# Altium schematic sheet Sheet1.SchDoc
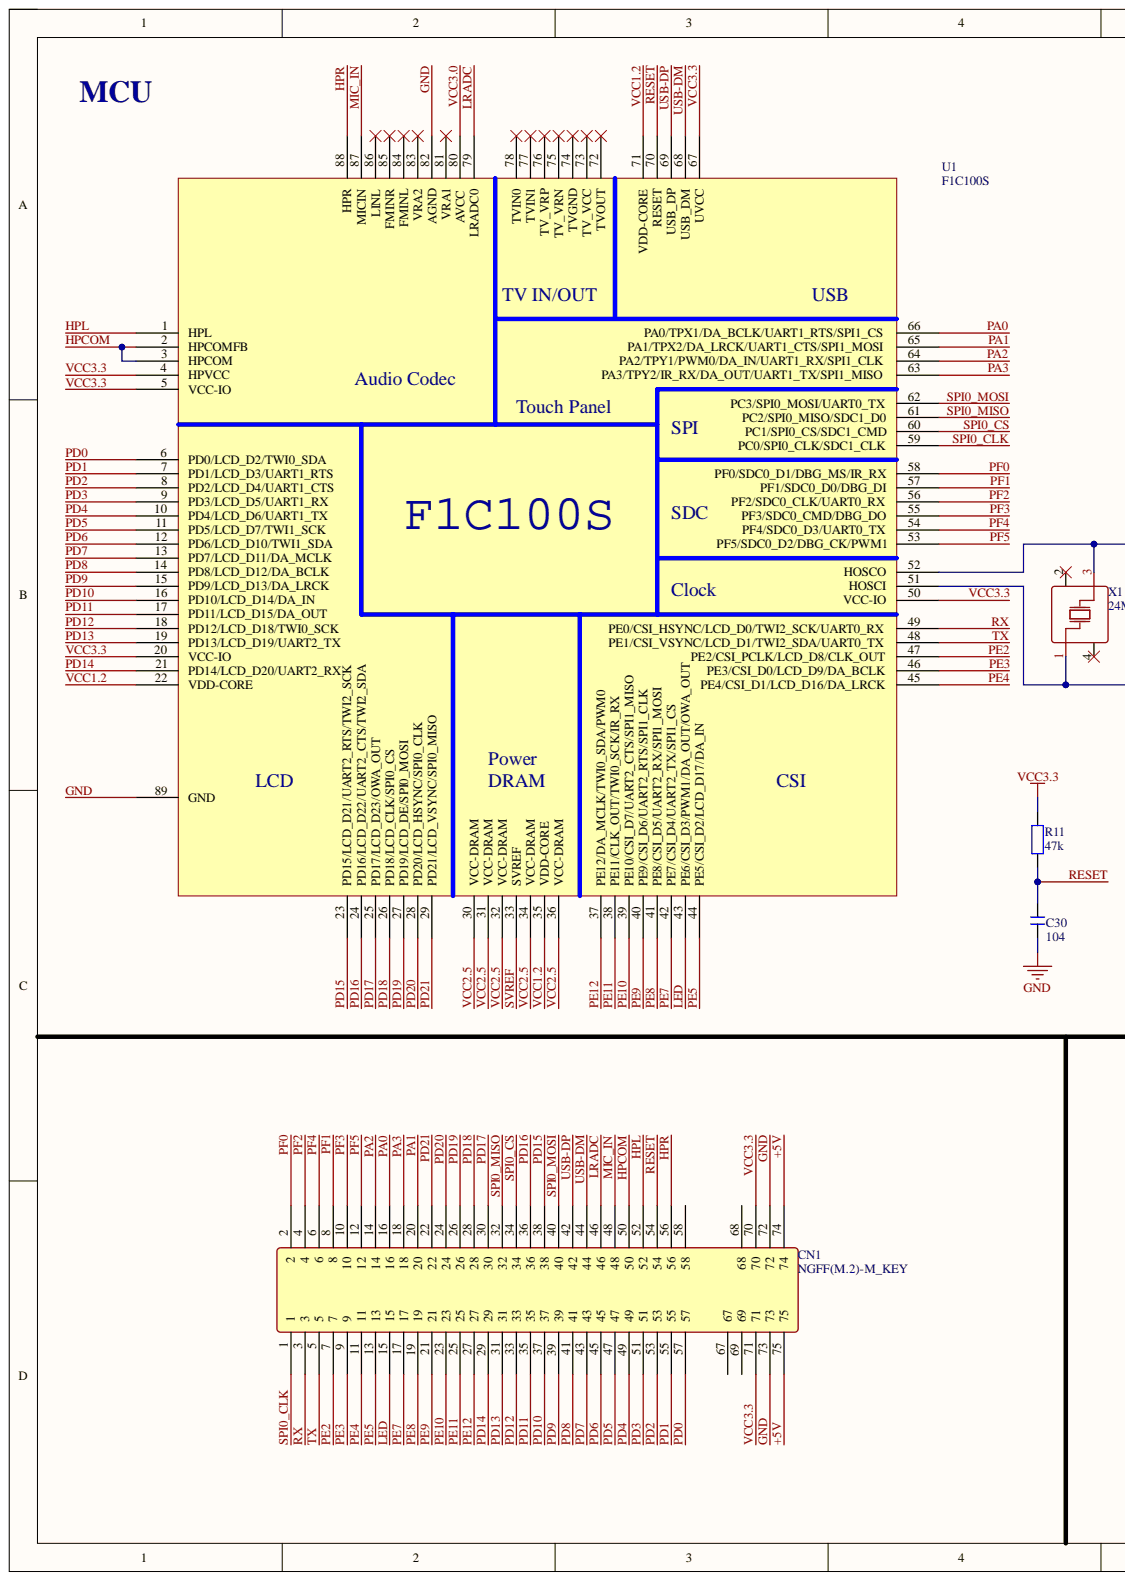
[diagram p0: Sheet1.SchDoc, left]
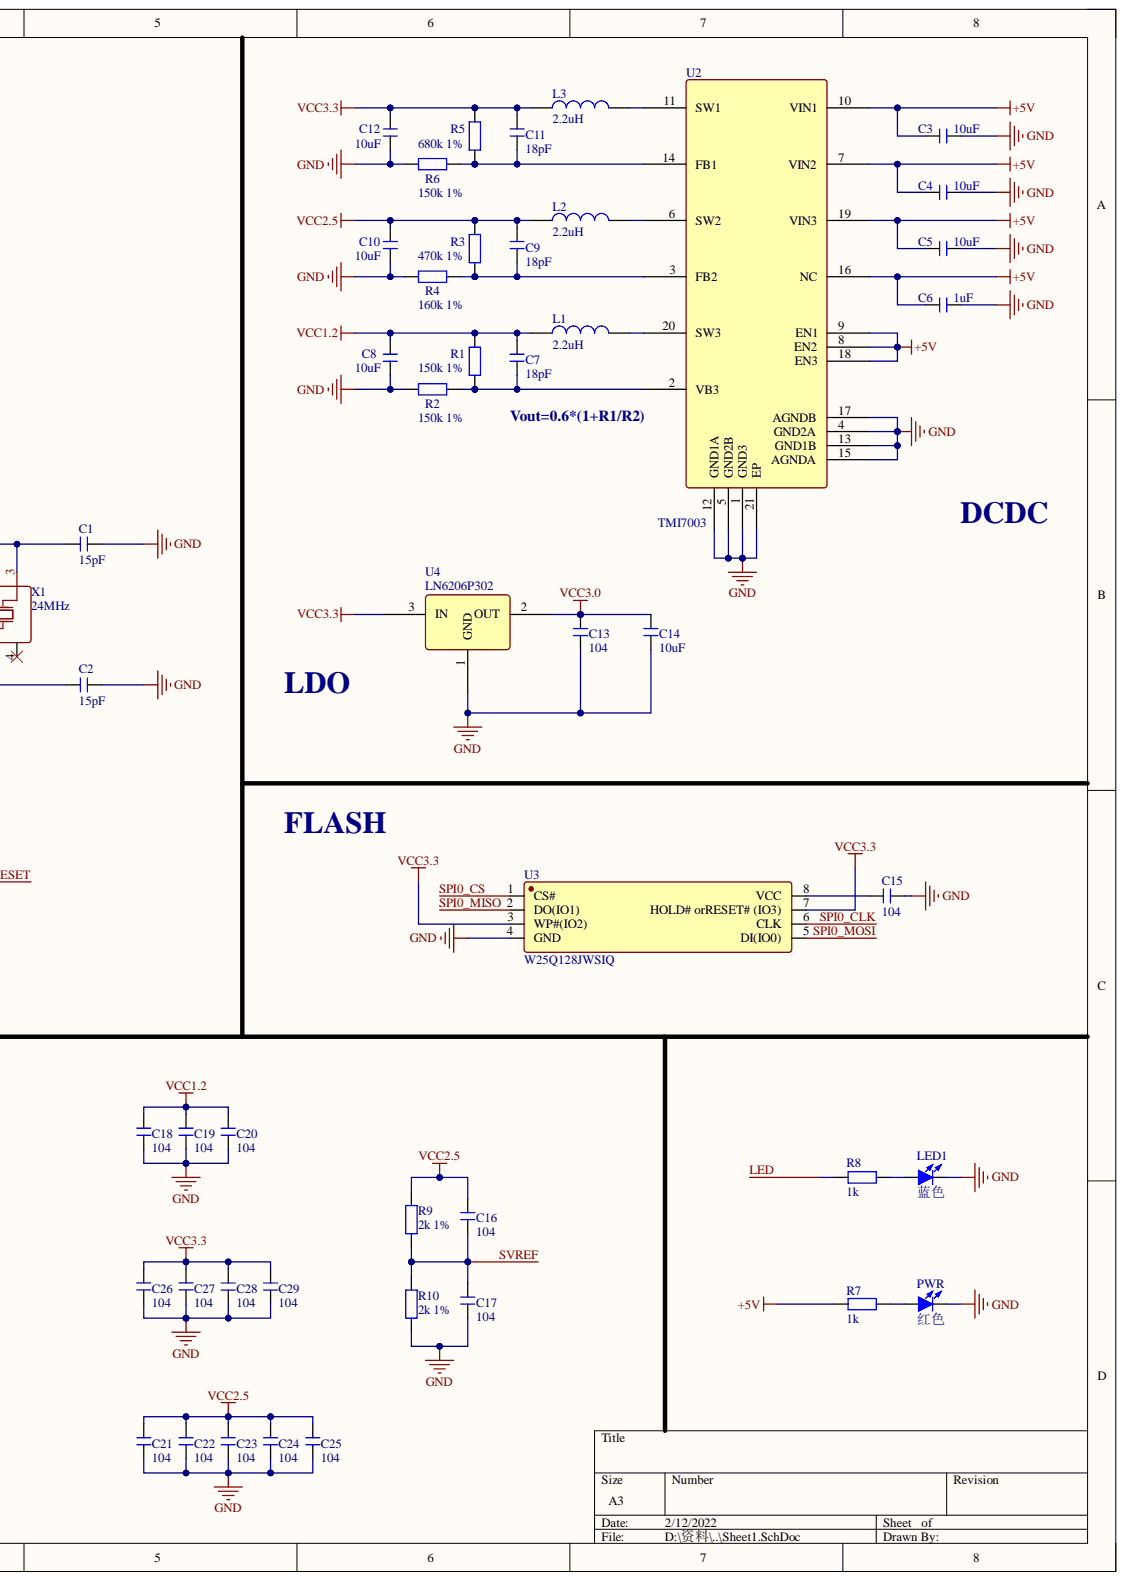
[diagram p1: Sheet1.SchDoc, right]

## Parts
C1  15pF  [C 0603_L]
C2  15pF  [C 0603_L]
C3  10uF  [C 0402_L]
C4  10uF  [C 0402_L]
C5  10uF  [C 0402_L]
C6  1uF  [C 0402_L]
C7  18pF  [C 0603_L]
C8  10uF  [C 0603_L]
C9  18pF  [C 0603_L]
C10  10uF  [C 0603_L]
C11  18pF  [C 0603_L]
C12  10uF  [C 0603_L]
C13  104  [C 0603_L]
C14  10uF  [C 0603_L]
C15  104  [C 0603_L]
C16  104  [C 0603_L]
C17  104  [C 0603_L]
C18  104  [C 0603_L]
C19  104  [C 0603_L]
C20  104  [C 0603_L]
C21  104  [C 0603_L]
C22  104  [C 0603_L]
C23  104  [C 0603_L]
C24  104  [C 0603_L]
C25  104  [C 0603_L]
C26  104  [C 0603_L]
C27  104  [C 0603_L]
C28  104  [C 0603_L]
C29  104  [C 0603_L]
C30  104  [C 0603_L]
CN1  NGFF(M.2)-M_KEY  [NGFF(M.2)-M_KEY]
L1  2.2uH  [L 0603]
L2  2.2uH  [L 0603]
L3  2.2uH  [L 0603]
LED1  蓝色  [LED_SMD_0805]
PWR  红色  [LED_SMD_0805]
R1  150k 1%  [R 0603_L]
R2  150k 1%  [R 0603_L]
R3  470k 1%  [R 0603_L]
R4  160k 1%  [R 0603_L]
R5  680k 1%  [R 0603_L]
R6  150k 1%  [R 0603_L]
R7  1k  [R 0603_L]
R8  1k  [R 0603_L]
R9  2k 1%  [R 0603_L]
R10  2k 1%  [R 0603_L]
R11  47k  [R 0603_L]
U1  F1C100S  [QFN88 10X10]
U2  TMI7003  [QFN20 3X3]
U3  W25Q128JWSIQ  [W25Q128JWSIQ]
U4  LN6206P302  [SOT-23-3L]
X1  24MHz  [OSC 3225-4P]

## Nets
+5V: C3.2 C4.2 C5.2 C6.2 CN1.74 CN1.75 R7.1 U2.7(VIN2) U2.8(EN2) U2.9(EN1) U2.10(VIN1) U2.16(NC) U2.18(EN3) U2.19(VIN3)
GND: C1.1 C2.1 C3.1 C4.1 C5.1 C6.1 C8.2 C10.2 C12.2 C13.2 C14.2 C15.2 C17.2 C18.2 C19.2 C20.2 C21.2 C22.2 C23.2 C24.2 C25.2 C26.2 C27.2 C28.2 C29.2 C30.2 CN1.72 CN1.73 LED1.2 PWR.2 R2.2 R4.2 R6.2 R10.2 U1.82(AGND) U1.89(GND) U2.1(GND3) U2.4(GND2A) U2.5(GND2B) U2.12(GND1A) U2.13(GND1B) U2.15(AGNDA) U2.17(AGNDB) U2.21(EP) U3.4(GND) U4.1
HPCOM: CN1.50 U1.2(HPCOMFB) U1.3(HPCOM)
HPL: CN1.52 U1.1(HPL)
HPR: CN1.56 U1.88(HPR)
LED: CN1.15 R8.1 U1.43(PE6/CSI_D3/PWM1/DA_OUT/OWA_OUT)
LRADC: CN1.46 U1.79(LRADC0)
MIC_IN: CN1.48 U1.87(MICIN)
PA0: CN1.16 U1.66(PA0/TPX1/DA_BCLK/UART1_RTS/SPI1_CS)
PA1: CN1.20 U1.65(PA1/TPX2/DA_LRCK/UART1_CTS/SPI1_MOSI)
PA2: CN1.14 U1.64(PA2/TPY1/PWM0/DA_IN/UART1_RX/SPI1_CLK)
PA3: CN1.18 U1.63(PA3/TPY2/IR_RX/DA_OUT/UART1_TX/SPI1_MISO)
PD0: CN1.57 U1.6(PD0/LCD_D2/TWI0_SDA)
PD1: CN1.55 U1.7(PD1/LCD_D3/UART1_RTS)
PD2: CN1.53 U1.8(PD2/LCD_D4/UART1_CTS)
PD3: CN1.51 U1.9(PD3/LCD_D5/UART1_RX)
PD4: CN1.49 U1.10(PD4/LCD_D6/UART1_TX)
PD5: CN1.47 U1.11(PD5/LCD_D7/TWI1_SCK)
PD6: CN1.45 U1.12(PD6/LCD_D10/TWI1_SDA)
PD7: CN1.43 U1.13(PD7/LCD_D11/DA_MCLK)
PD8: CN1.41 U1.14(PD8/LCD_D12/DA_BCLK)
PD9: CN1.39 U1.15(PD9/LCD_D13/DA_LRCK)
PD10: CN1.37 U1.16(PD10/LCD_D14/DA_IN)
PD11: CN1.35 U1.17(PD11/LCD_D15/DA_OUT)
PD12: CN1.33 U1.18(PD12/LCD_D18/TWI0_SCK)
PD13: CN1.31 U1.19(PD13/LCD_D19/UART2_TX)
PD14: CN1.29 U1.21(PD14/LCD_D20/UART2_RX)
PD15: CN1.38 U1.23(PD15/LCD_D21/UART2_RTS/TWI2_SCK)
PD16: CN1.36 U1.24(PD16/LCD_D22/UART2_CTS/TWI2_SDA)
PD17: CN1.30 U1.25(PD17/LCD_D23/OWA_OUT)
PD18: CN1.28 U1.26(PD18/LCD_CLK/SPI0_CS)
PD19: CN1.26 U1.27(PD19/LCD_DE/SPI0_MOSI)
PD20: CN1.24 U1.28(PD20/LCD_HSYNC/SPI0_CLK)
PD21: CN1.22 U1.29(PD21/LCD_VSYNC/SPI0_MISO)
PE2: CN1.7 U1.47(PE2/CSI_PCLK/LCD_D8/CLK_OUT)
PE3: CN1.9 U1.46(PE3/CSI_D0/LCD_D9/DA_BCLK)
PE4: CN1.11 U1.45(PE4/CSI_D1/LCD_D16/DA_LRCK)
PE5: CN1.13 U1.44(PE5/CSI_D2/LCD_D17/DA_IN)
PE7: CN1.17 U1.42(PE7/CSI_D4/UART2_TX/SPI1_CS)
PE8: CN1.19 U1.41(PE8/CSI_D5/UART2_RX/SPI1_MOSI)
PE9: CN1.21 U1.40(PE9/CSI_D6/UART2_RTS/SPI1_CLK)
PE10: CN1.23 U1.39(PE10/CSI_D7/UART2_CTS/SPI1_MISO)
PE11: CN1.25 U1.38(PE11/CLK_OUT/TWI0_SCK/IR_RX)
PE12: CN1.27 U1.37(PE12/DA_MCLK/TWI0_SDA/PWM0)
PF0: CN1.2 U1.58(PF0/SDC0_D1/DBG_MS/IR_RX)
PF1: CN1.8 U1.57(PF1/SDC0_D0/DBG_DI)
PF2: CN1.4 U1.56(PF2/SDC0_CLK/UART0_RX)
PF3: CN1.10 U1.55(PF3/SDC0_CMD/DBG_DO)
PF4: CN1.6 U1.54(PF4/SDC0_D3/UART0_TX)
PF5: CN1.12 U1.53(PF5/SDC0_D2/DBG_CK/PWM1)
RESET: C30.1 CN1.54 R11.2 U1.70(RESET)
RX: CN1.3 U1.49(PE0/CSI_HSYNC/LCD_D0/TWI2_SCK/UART0_RX)
SPI0_CLK: CN1.1 U1.59(PC0/SPI0_CLK/SDC1_CLK) U3.6(CLK)
SPI0_CS: CN1.34 U1.60(PC1/SPI0_CS/SDC1_CMD) U3.1(CS#)
SPI0_MISO: CN1.32 U1.61(PC2/SPI0_MISO/SDC1_D0) U3.2(DO(IO1))
SPI0_MOSI: CN1.40 U1.62(PC3/SPI0_MOSI/UART0_TX) U3.5(DI(IO0))
SVREF: C16.2 C17.1 R9.2 R10.1 U1.33(SVREF)
TX: CN1.5 U1.48(PE1/CSI_VSYNC/LCD_D1/TWI2_SDA/UART0_TX)
USB-DM: CN1.44 U1.68(USB_DM)
USB-DP: CN1.42 U1.69(USB_DP)
VCC1.2: C7.1 C8.1 C18.1 C19.1 C20.1 L1.1 R1.2 U1.22(VDD-CORE) U1.35(VDD-CORE) U1.71(VDD-CORE)
VCC2.5: C9.1 C10.1 C16.1 C21.1 C22.1 C23.1 C24.1 C25.1 L2.1 R3.2 R9.1 U1.30(VCC-DRAM) U1.31(VCC-DRAM) U1.32(VCC-DRAM) U1.34(VCC-DRAM) U1.36(VCC-DRAM)
VCC3.0: C13.1 C14.1 U1.80(AVCC) U4.2
VCC3.3: C11.1 C12.1 C26.1 C27.1 C28.1 C29.1 CN1.70 CN1.71 L3.1 R5.2 R11.1 U1.4(HPVCC) U1.5(VCC-IO) U1.20(VCC-IO) U1.50(VCC-IO) U1.67(UVCC) U3.3(WP#(IO2)) U3.7(HOLD#_orRESET#_(IO3)) U4.3
NetC1_2: C1.2 U1.52(HOSCO) X1.3
NetC2_2: C2.2 U1.51(HOSCI) X1.1
NetC7_2: C7.2 R1.1 R2.1 U2.2(VB3)
NetC9_2: C9.2 R3.1 R4.1 U2.3(FB2)
NetC11_2: C11.2 R5.1 R6.1 U2.14(FB1)
NetC15_1: C15.1 U3.8(VCC)
NetL1_2: L1.2 U2.20(SW3)
NetL2_2: L2.2 U2.6(SW2)
NetL3_2: L3.2 U2.11(SW1)
NetLED1_1: LED1.1 R8.2
NetPWR_1: PWR.1 R7.2

Not connected: CN1 58 67 68 69; U1 72(TVOUT) 73(TV_VCC) 74(TVGND) 75(TV_VRN) 76(TV_VRP) 77(TVIN1) 78(TVIN0) 81(VRA1) 83(VRA2) 84(FMINL) 85(FMINR) 86(LINL); X1 2 4

## Library data of the parts
C1-C30 = C: 无极性贴片电容; 版权归属: 源创客; BOM名称: 无极性贴片电容; Component Kind: Standard; Library Name: Z-LibAll.IntLib; 学习资源: www.ooocke.com
L1-L3 = L: 小功率贴片电感; 版权归属: 源创客; BOM名称: 小功率贴片电感; Component Kind: Standard; Library Name: Z-LibAll.IntLib; 学习资源: www.ooocke.com
LED1 PWR = LED-SMD: 贴片LED; Component Kind: Standard; Library Name: Z-LibAll.IntLib
R1-R11 = R: 贴片电阻; 版权归属: 源创客; BOM名称: 贴片电阻; Component Kind: Standard; Library Name: Z-LibAll.IntLib; 学习资源: www.ooocke.com
U1 = F1C100S: 全志F1C100S; Component Kind: Standard; Library Name: Schlib.SchLib
U2 = TMI7003: LC_C708882; Component Kind: Standard; Library Name: Schlib.SchLib
U4 = SOT-23-3L: Component Kind: Standard; Library Name: Z-LibAll.IntLib
X1 = XTAL: LC-C255980; Component Kind: Standard; Library Name: Z-LibAll.IntLib
CN1 = NGFF(M.2)-M_KEY: Component Kind: Standard; Library Name: Schlib.SchLib
U3 = W25Q128JWSIQ: Component Kind: Standard; Library Name: Z-LibAll.IntLib
